# Altium schematic sheet HHOLowCost.SCHDOC(HHOLowCost)
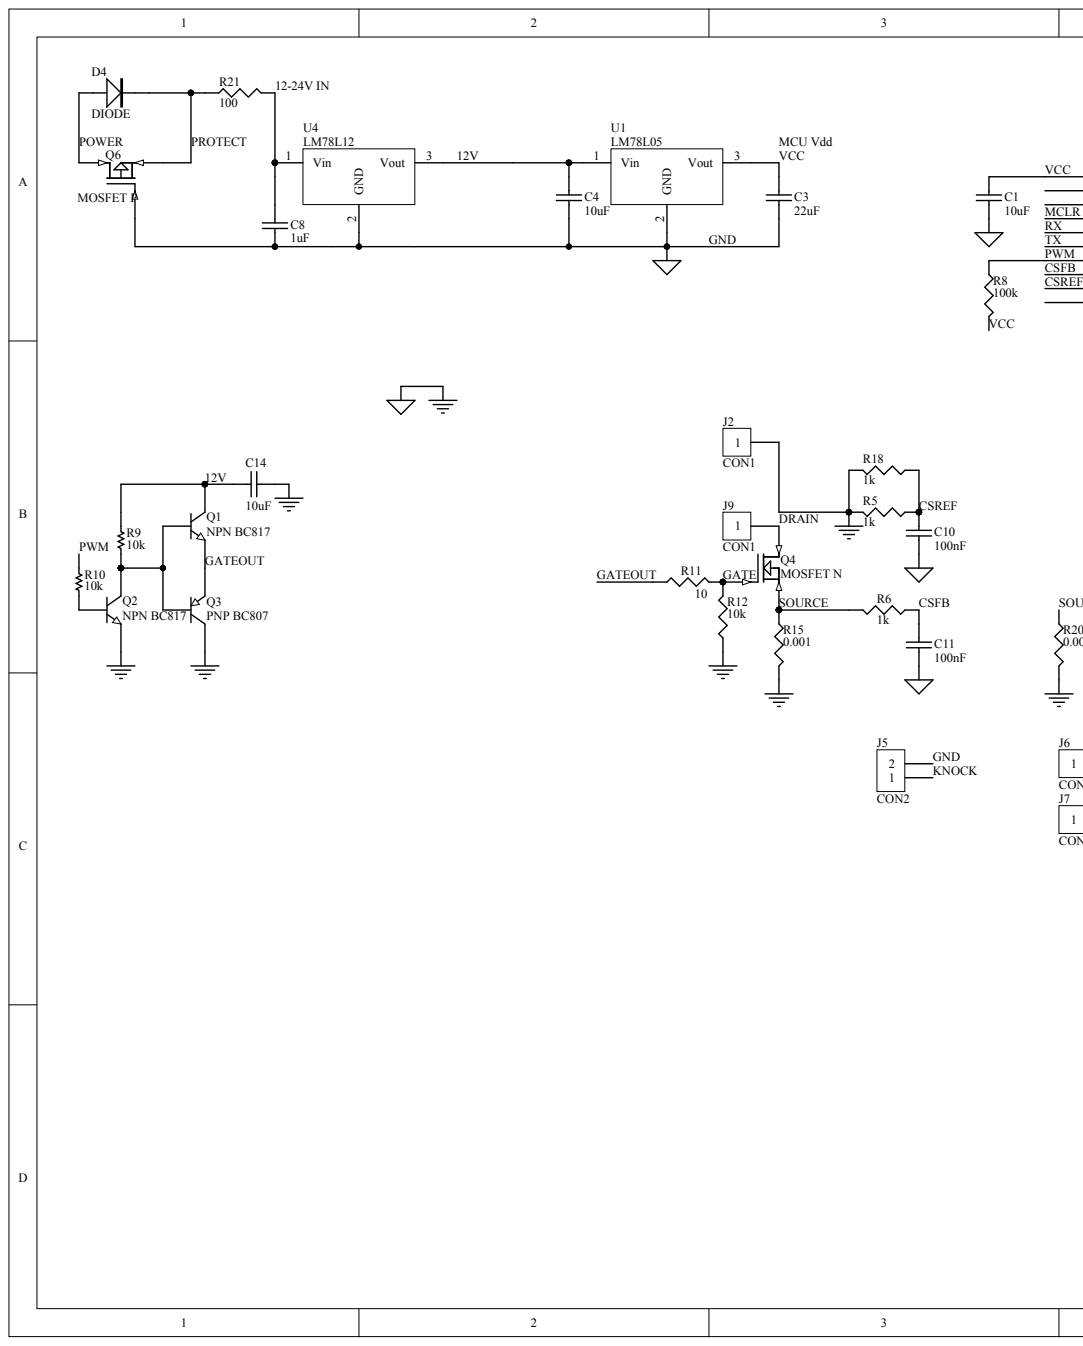
[diagram p0: HHOLowCost.SCHDOC, left]
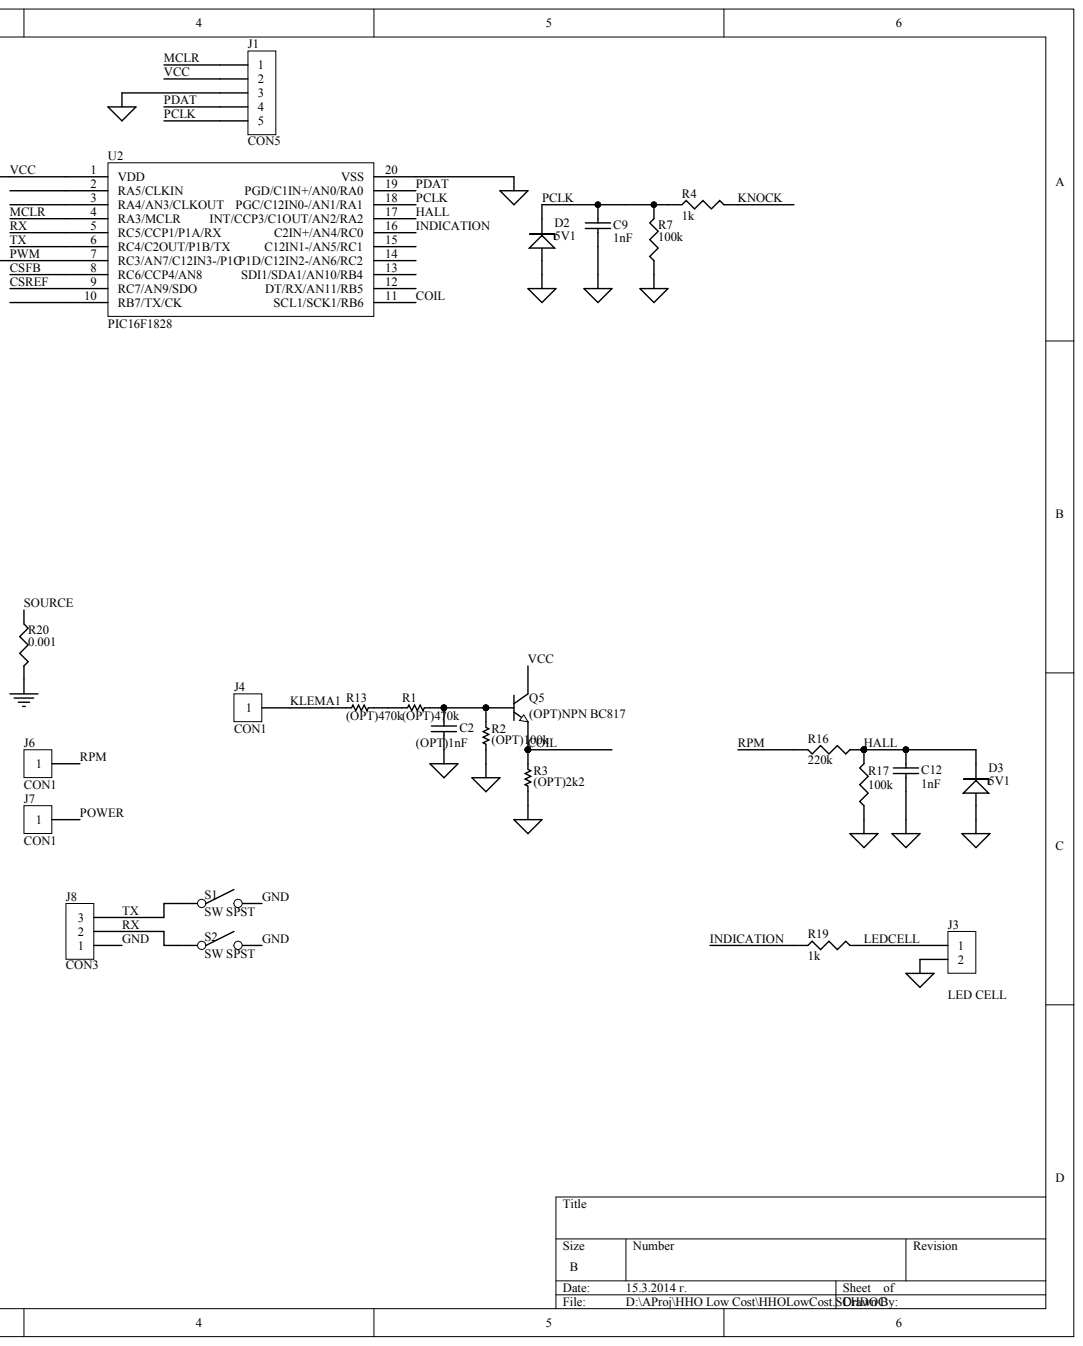
[diagram p1: HHOLowCost.SCHDOC, right]

## Parts
C1  10uF
C2  (OPT)1nF
C3  22uF
C4  10uF
C8  1uF
C9  1nF
C10  100nF
C11  100nF
C12  1nF
C14  10uF
D2  5V1
D3  5V1
D4  DIODE
J1  CON5
J2  CON1
J3  LED CELL
J4  CON1
J5  CON2
J6  CON1
J7  CON1
J8  CON3
J9  CON1
Q1  NPN BC817
Q2  NPN BC817
Q3  PNP BC807
Q4  MOSFET N
Q5  (OPT)NPN BC817
Q6  MOSFET P
R1  (OPT)470k
R2  (OPT)100k
R3  (OPT)2k2
R4  1k
R5  1k
R6  1k
R7  100k
R8  100k
R9  10k
R10  10k
R11  10
R12  10k
R13  (OPT)470k
R15  0.001
R16  220k
R17  100k
R18  1k
R19  1k
R20  0.001
R21  100
S1  SW SPST
S2  SW SPST
U1  LM78L05
U2  PIC16F1828
U4  LM78L12

## Nets
12-24V IN: C8.1 R21.2 U4.1
12V: C4.1 C14.2 Q1.2 R9.1 U1.1 U4.3
COIL: Q5.3 R3.1 U2.11(SCL1/SCK1/RB6)
CSFB: C11.1 R6.1 U2.8(RC6/CCP4/AN8)
CSREF: C10.1 R5.1 R18.1 U2.9(RC7/AN9/SDO)
DRAIN: J9.1 Q4.2
GATE: Q4.1 R11.2 R12.2
GATEOUT: Q1.3 Q3.3 R11.1
GND: C1.2 C2.2 C3.2 C4.2 C8.2 C9.2 C10.2 C11.2 C12.2 C14.1 D2.1 D3.1 J1.3 J2.1 J3.2 J5.2 J8.1 Q2.3 Q3.2 Q6.1 R2.2 R3.2 R5.2 R7.1 R12.1 R15.2 R17.1 R18.2 R20.2 S1.2 S2.2 U1.2 U2.20(VSS) U4.2
HALL: C12.1 D3.2 R16.1 R17.2 U2.17(INT/CCP3/C1OUT/AN2/RA2)
INDICATION: R19.1 U2.16(C2IN+/AN4/RC0)
KLEMA1: J4.1 R13.2
KNOCK: J5.1 R4.2
LEDCELL: J3.1 R19.2
MCLR: J1.1 U2.4(RA3/MCLR)
PCLK: C9.1 D2.2 J1.5 R4.1 R7.2 U2.18(PGC/C12IN0-/AN1/RA1)
PDAT: J1.4 U2.19(PGD/C1IN+/AN0/RA0)
POWER: D4.A J7.1 Q6.2
PROTECT: D4.K Q6.3 R21.1
PWM: R8.1 R10.1 U2.7(RC3/AN7/C12IN3-/P1C)
RPM: J6.1 R16.2
RX: J8.2 S2.1 U2.5(RC5/CCP1/P1A/RX)
SOURCE: Q4.3 R6.2 R15.1 R20.1
TX: J8.3 S1.1 U2.6(RC4/C2OUT/P1B/TX)
VCC: C1.1 C3.1 J1.2 Q5.2 R8.2 U1.3 U2.1(VDD)
NetC2_1: C2.1 Q5.1 R1.1 R2.1
NetQ1_1: Q1.1 Q2.2 Q3.1 R9.2
NetQ2_1: Q2.1 R10.2
NetR1_2: R1.2 R13.1

Not connected: U2 2(RA5/CLKIN) 3(RA4/AN3/CLKOUT) 10(RB7/TX/CK) 12(DT/RX/AN11/RB5) 13(SDI1/SDA1/AN10/RB4) 14(P1D/C12IN2-/AN6/RC2) 15(C12IN1-/AN5/RC1)

## Library data of the parts
Q1-Q3 Q5 = (no symbol name): Manufacturer: Generic Components; Class: Discrete; SubClass: BJT - Low Power; Published: 08/06/2000; Publisher: Altium Hobart Technology Centre
R1-R3 R9 R10 R13 = (no symbol name): Manufacturer: Generic Components; Class: Passive; SubClass: Resistor; Value: 4k7; Published: 08/06/2000; Publisher: Altium Hobart Technology Centre
